# Altium schematic sheet High Voltage PCB 2019.SchDoc("High Voltage PCB 2019")
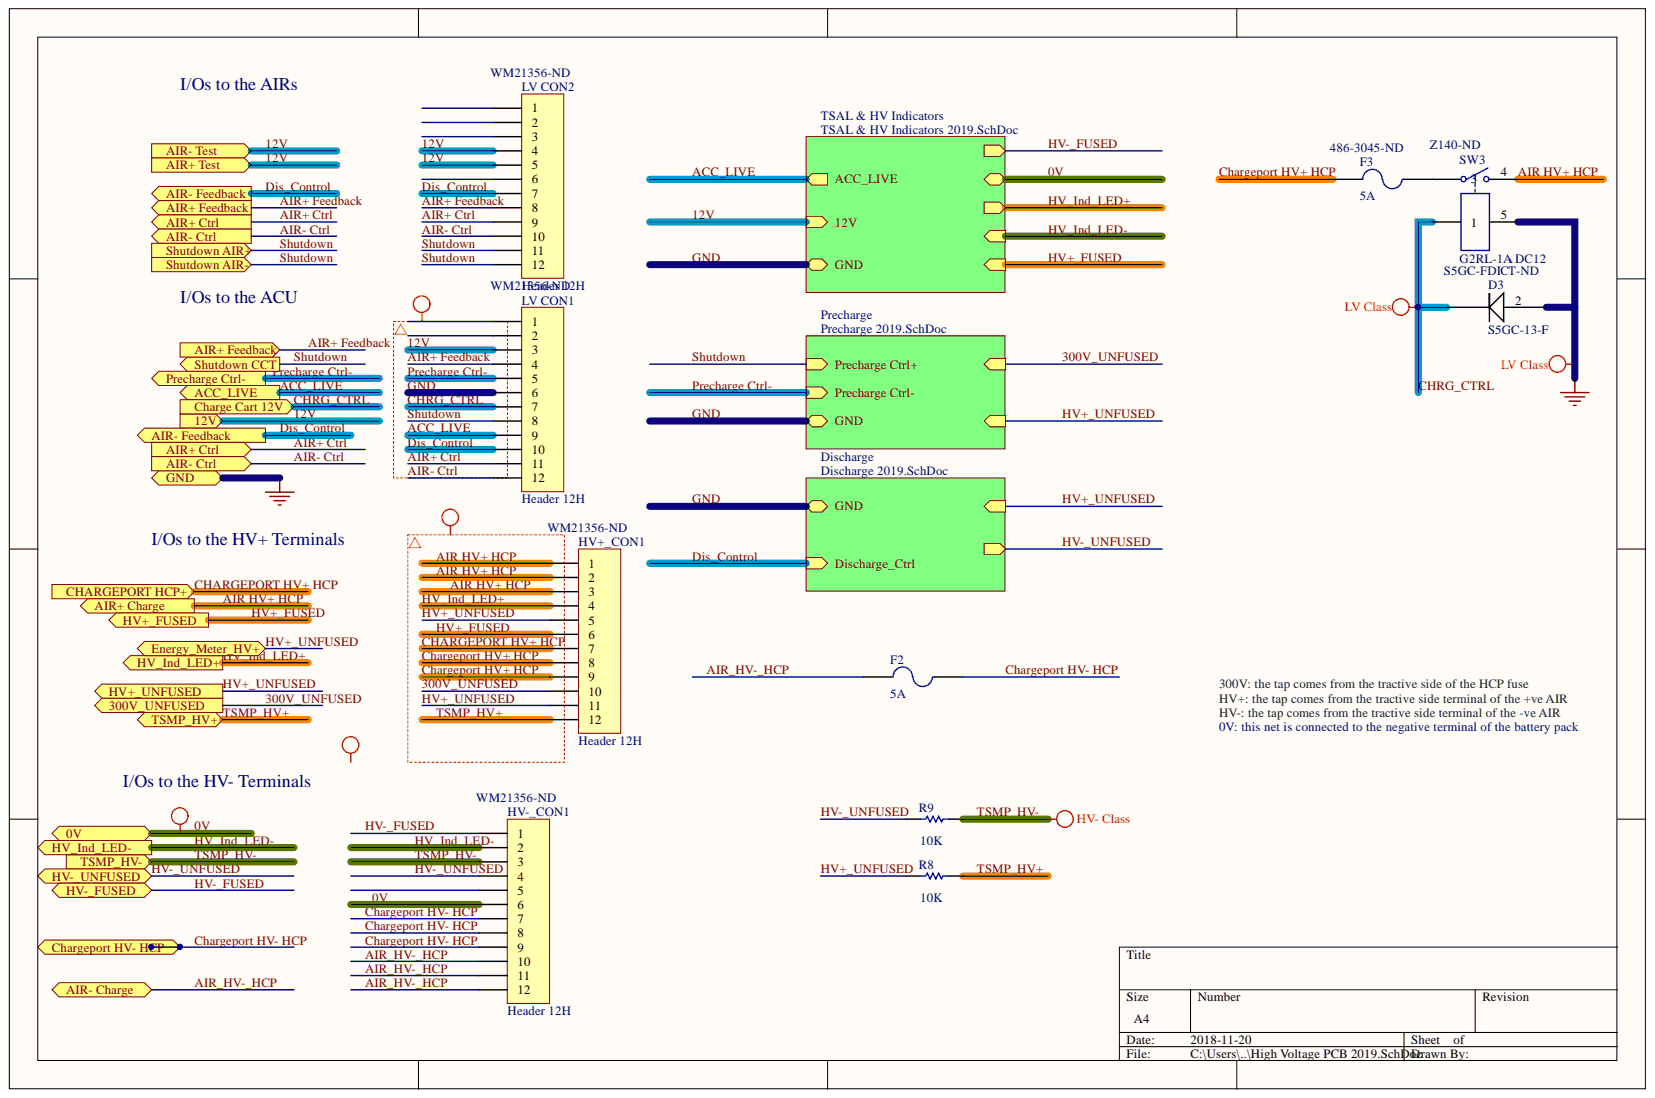
[diagram p0: High Voltage PCB 2019.SchDoc]

## Parts
D3  S5GC-13-F  Supplier Part Number 1: S5GC-FDICT-ND  [DO-214AB]
F2  5A  [FUSEHOLDER10x38]
F3  5A  Supplier Part Number 2: 486-3045-ND  [FUSEHOLDER10x38]
HV+_CON1  Header 12H  Supplier Part Number 1: WM21356-ND  [PCBComponent_1]
HV-_CON1  Header 12H  Supplier Part Number 1: WM21356-ND  [PCBComponent_1]
LV_CON1  Header 12H  Supplier Part Number 1: WM21356-ND  [PCBComponent_1]
LV_CON2  Header 12H  Supplier Part Number 1: WM21356-ND  [PCBComponent_1]
R8  10K  [Res 10K 3W]
R9  10K  [Res 10K 3W]
SW3  G2RL-1A DC12  Supplier Part Number 1: Z140-ND  [SPST-NO - G2RL-1A]

## Ports
0V, 12V, 300V_UNFUSED, ACC_LIVE, AIR+ Charge, AIR+ Ctrl, AIR+ Feedback, AIR+ Test, AIR- Charge, AIR- Ctrl, AIR- Feedback, AIR- Test, Charge Cart 12V, CHARGEPORT HCP+, Chargeport HV- HCP, Energy_Meter_HV+, GND, HV+_FUSED, HV+_UNFUSED, HV-_FUSED, HV-_UNFUSED, HV_Ind_LED+, HV_Ind_LED-, Precharge Ctrl-, Shutdown AIR+, Shutdown AIR-, Shutdown CCT, TSMP_HV+, TSMP_HV-

## Nets
0V: HV-_CON1.6 ; port 0V
12V: LV_CON1.3 LV_CON2.4 LV_CON2.5 ; port 12V
300V_UNFUSED: HV+_CON1.10 ; port 300V_UNFUSED
ACC_LIVE: LV_CON1.9 ; port ACC_LIVE
AIR HV+ HCP: HV+_CON1.1 HV+_CON1.2 HV+_CON1.3 SW3.4
AIR+ Ctrl: LV_CON1.11 LV_CON2.9 ; port AIR+ Ctrl
AIR+ Feedback: LV_CON1.4 LV_CON2.8 ; port AIR+ Feedback
AIR- Ctrl: LV_CON1.12 LV_CON2.10 ; port AIR- Ctrl
AIR_HV-_HCP: F2.2 HV-_CON1.10 HV-_CON1.11 HV-_CON1.12
CHARGEPORT HV+ HCP: F3.2 HV+_CON1.7 HV+_CON1.8 HV+_CON1.9 ; port CHARGEPORT HCP+
Chargeport HV- HCP: F2.1 HV-_CON1.7 HV-_CON1.8 HV-_CON1.9 ; port Chargeport HV- HCP
CHRG_CTRL: D3.1 LV_CON1.7 SW3.1 ; port Charge Cart 12V
Dis_Control: LV_CON1.10 LV_CON2.7
GND: D3.2 LV_CON1.6 SW3.5 ; port GND
HV+_FUSED: HV+_CON1.6 ; port HV+_FUSED
HV+_UNFUSED: HV+_CON1.5 HV+_CON1.11 R8.2 ; port Energy_Meter_HV+, port HV+_UNFUSED
HV-_FUSED: HV-_CON1.1 ; port HV-_FUSED
HV-_UNFUSED: HV-_CON1.4 R9.2 ; port HV-_UNFUSED
HV_Ind_LED+: HV+_CON1.4 ; port HV_Ind_LED+
HV_Ind_LED-: HV-_CON1.2 ; port HV_Ind_LED-
Precharge Ctrl-: LV_CON1.5 ; port Precharge Ctrl-
Shutdown: LV_CON1.8 LV_CON2.11 LV_CON2.12 ; port Shutdown CCT
TSMP_HV+: HV+_CON1.12 R8.1 ; port TSMP_HV+
TSMP_HV-: HV-_CON1.3 R9.1 ; port TSMP_HV-
NetF3_1: F3.1 SW3.3
NetHV-_CON1_5: HV-_CON1.5
NetLV CON1_1: LV_CON1.1
NetLV CON1_2: LV_CON1.2
NetLV CON2_1: LV_CON2.1
NetLV CON2_2: LV_CON2.2
NetLV CON2_3: LV_CON2.3
NetLV CON2_6: LV_CON2.6
N00029:
N00030:

## Library data of the parts
D3 = DIODE 1N4001: DIODE GEN PURP 400V 5A SMC; Supplier 1: Digi-Key; Library Name: FE Schem Lib.SchLib; Component Kind: Standard
F2 F3 = Fuse 2: 0751.0506: FUSE HOLDER SOLDER TYPE; Supplier 2: Digi-Key; Simulation: FUSE; Published: 8-Jun-2000; PackageReference: PIN-W2/E2.8; PackageDescription: Thru-Hole; 2 Leads; Library Name: Miscellaneous Devices.IntLib; LatestRevisionNote: Re-released for DXP Platform.; LatestRevisionDate: 17-Jul-2002; Component Kind: Standard; Publisher: Altium Limited
  F2: Supplier Part Number 2: 486-3045-ND
HV+_CON1 HV-_CON1 LV_CON1 LV_CON2 = Header 12H: Header, 12-Pin, Right Angle; Supplier 1: Digi-Key; Signal Integrity: Connector; Library Name: Miscellaneous Connectors.IntLib; LatestRevisionNote: Re-released for DXP Platform.; LatestRevisionDate: 17-Jul-2002; Component Kind: Standard; Publisher: Altium Limited
R8 R9 = Res1: 352210KJT: RES SMD 10K OHM 5% 3W 2512; Value: 10K; Supplier Part Number 1: A121121CT-ND; Supplier 1: Digi-Key; Simulation: RESISTOR; Signal Integrity: Res; Published: 8-Jun-2000; PackageReference: AXIAL-0.3; PackageDescription: Axial Device, Thru-Hole; 2 Leads; 0.3 in Pin Spacing; Library Name: Miscellaneous Devices.IntLib; LatestRevisionNote: Re-released for DXP Platform.; LatestRevisionDate: 17-Jul-2002; Component Kind: Standard; Publisher: Altium Limited
SW3 = Relay-SPST: RELAY GEN PURPOSE SPST 12A 12V; Supplier 1: Digi-Key; Published: 24-Mar-1999; PackageReference: MODULE4; Library Name: Miscellaneous Devices.IntLib; LatestRevisionNote: Re-released for DXP Platform.; LatestRevisionDate: 17-Jul-2002; Component Kind: Standard; Publisher: Altium Limited
